# Altium schematic sheet AA83_ProberCard_V0.SchDoc
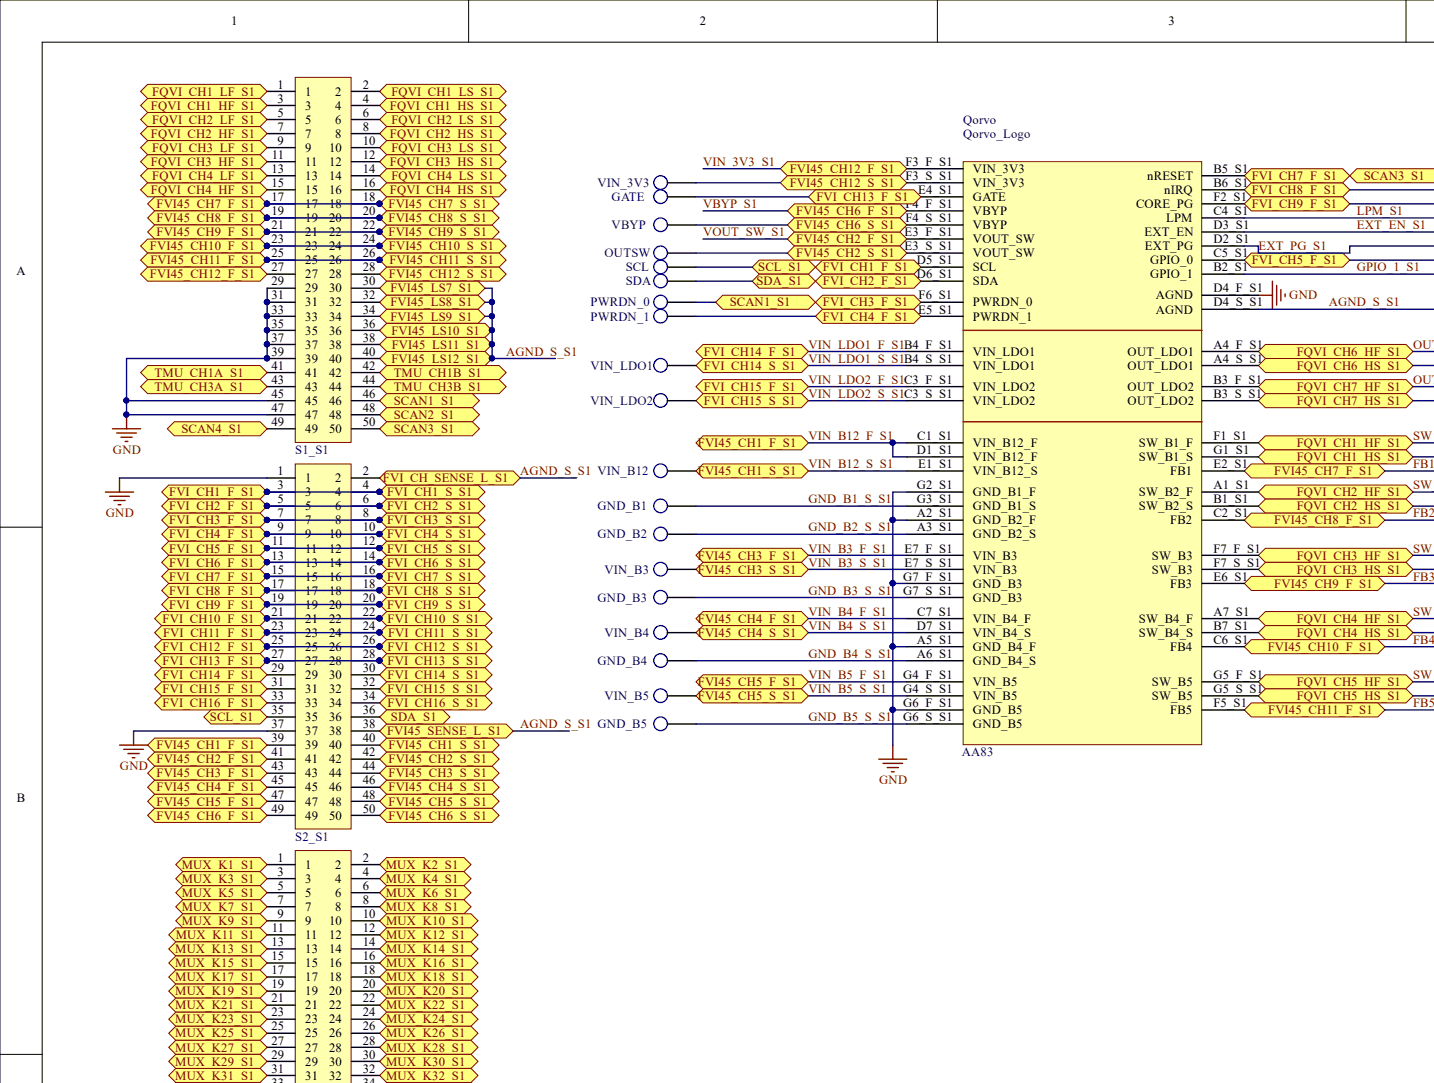
[diagram p0: AA83_ProberCard_V0.SchDoc, top left]
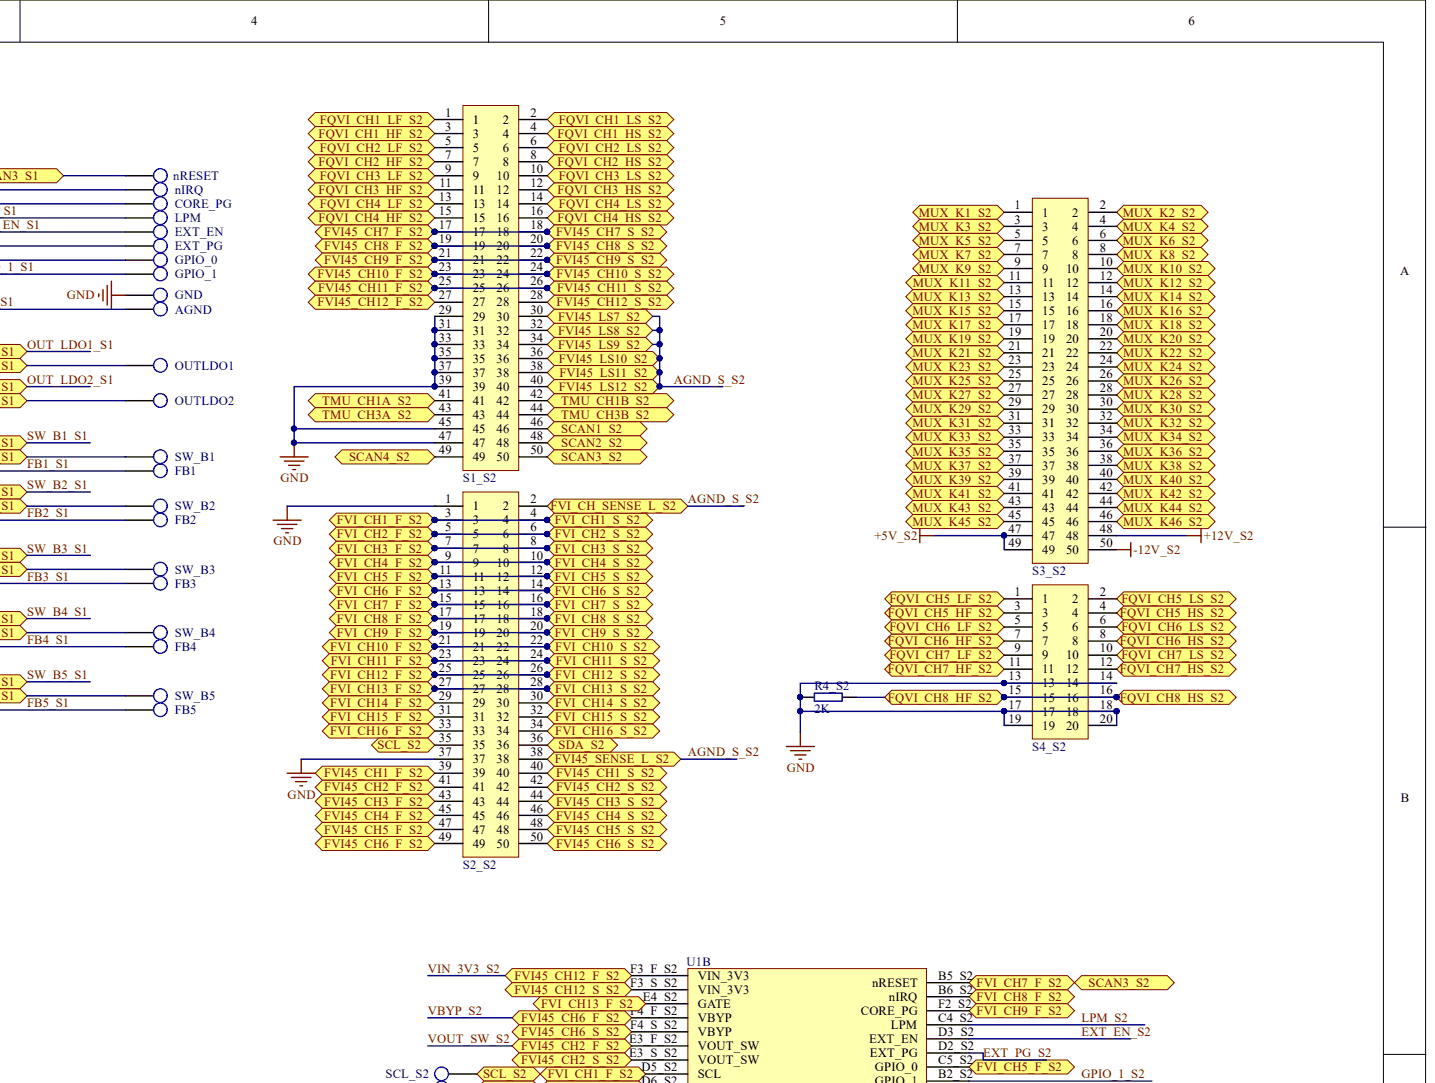
[diagram p1: AA83_ProberCard_V0.SchDoc, top right]
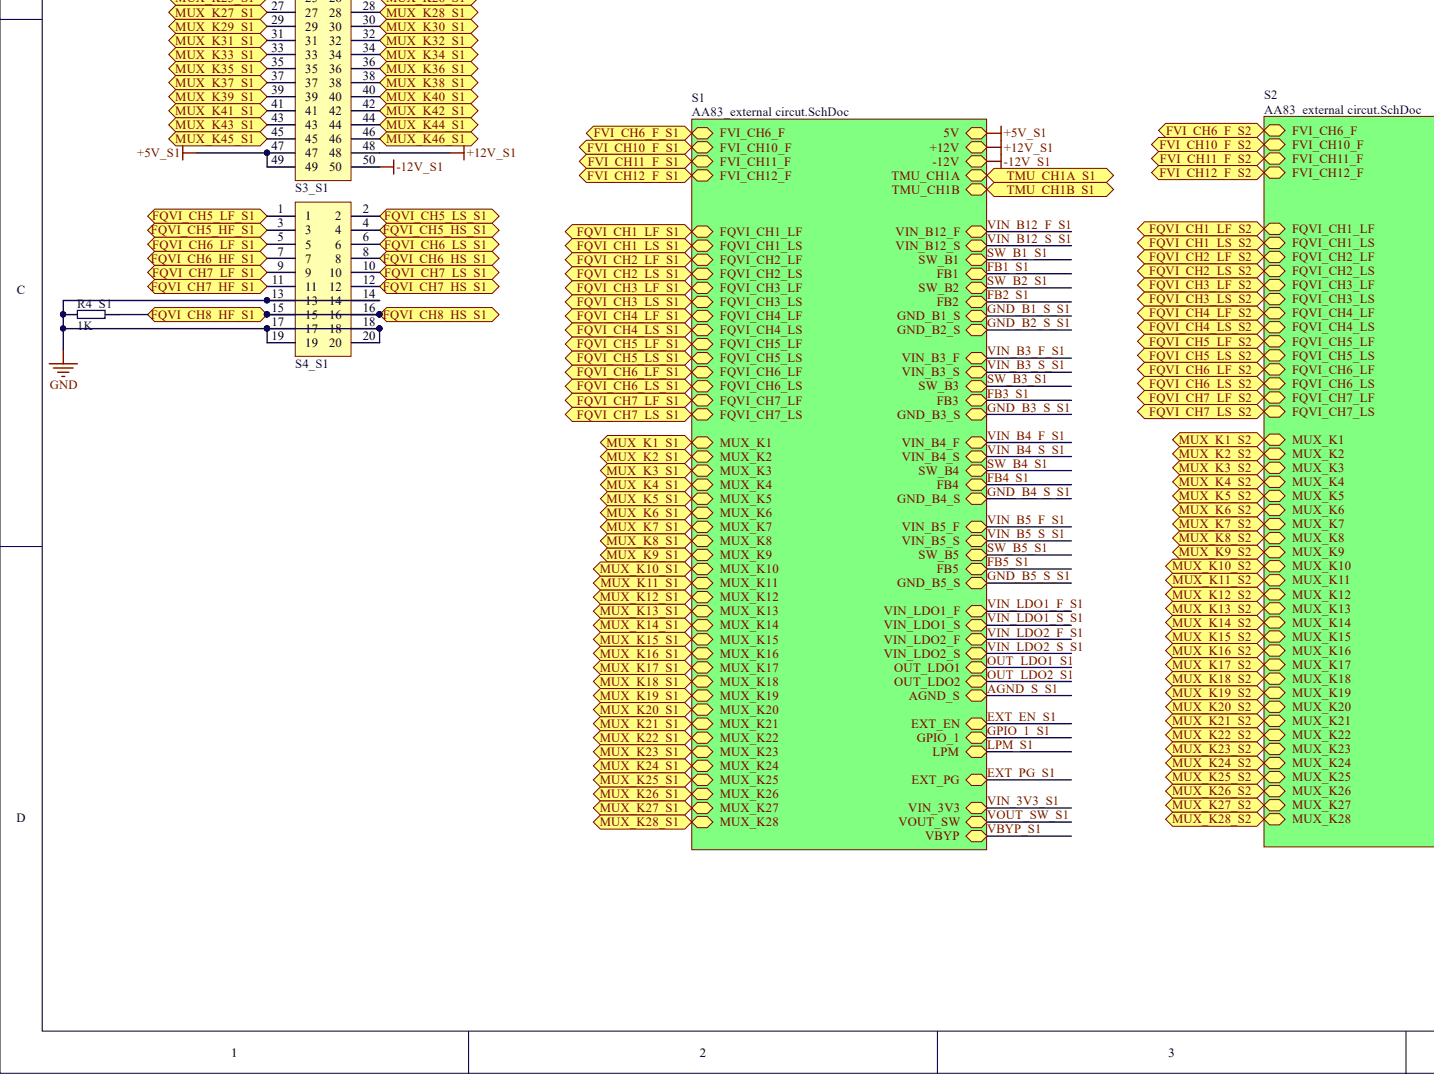
[diagram p2: AA83_ProberCard_V0.SchDoc, bottom left]
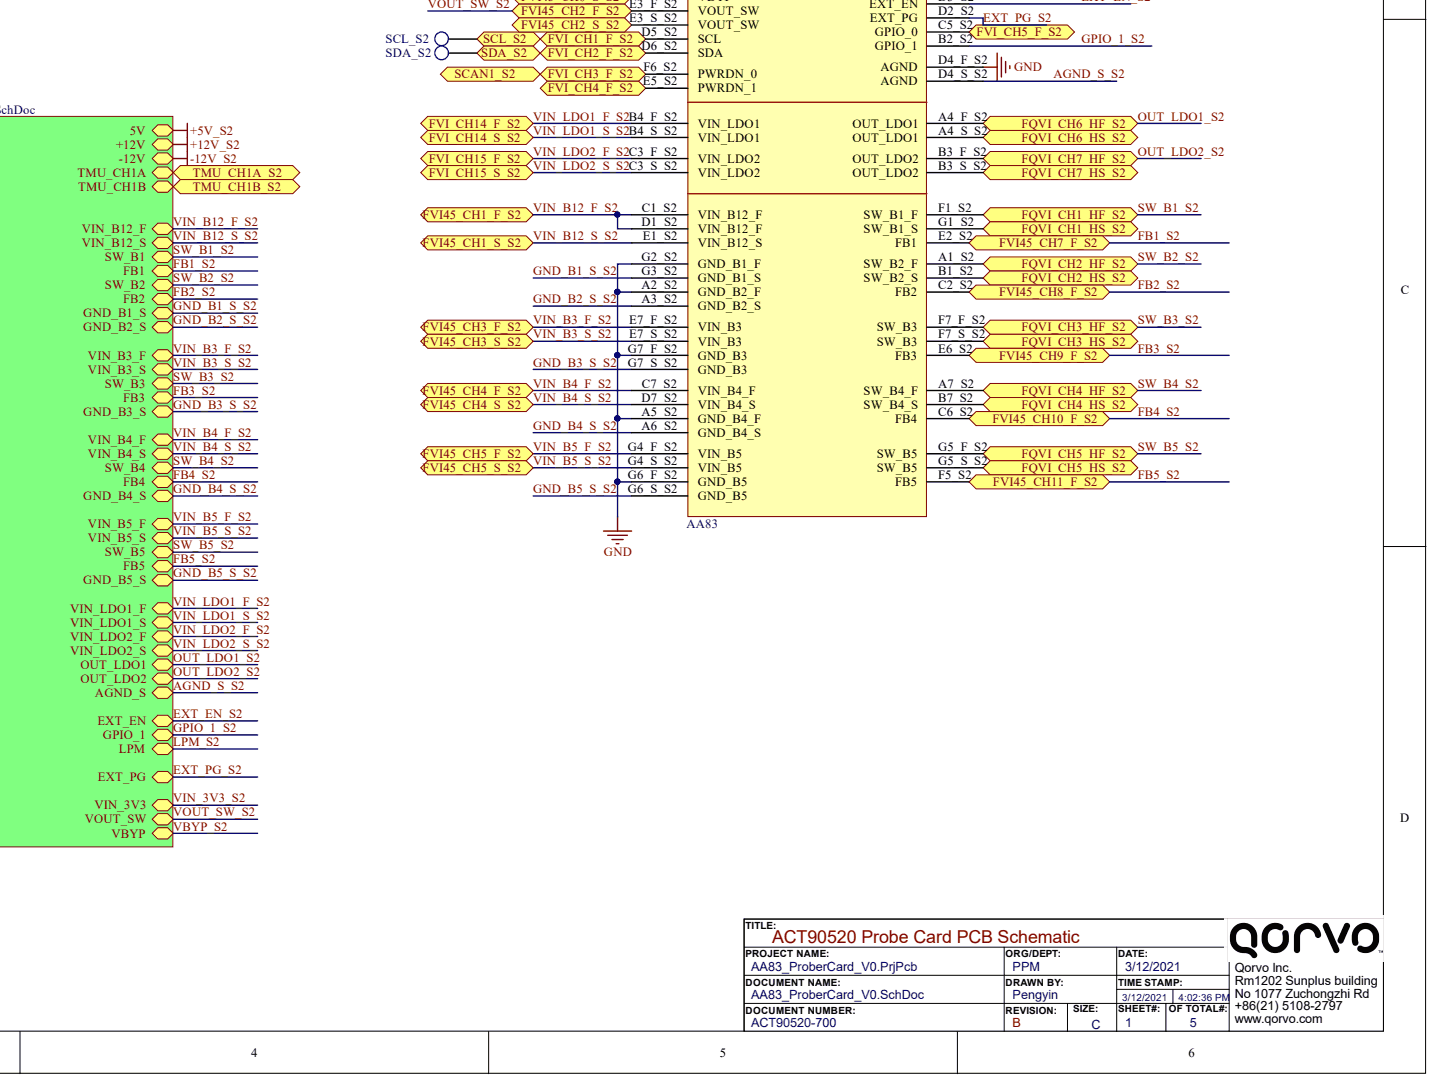
[diagram p3: AA83_ProberCard_V0.SchDoc, bottom right]

## Parts
AGND  TP  [TP]  (value not drawn)
CORE_PG  TP  [TP]  (value not drawn)
EXT_EN  TP  [TP]  (value not drawn)
EXT_PG  TP  [TP]  (value not drawn)
FB1  TP  [TP]  (value not drawn)
FB2  TP  [TP]  (value not drawn)
FB3  TP  [TP]  (value not drawn)
FB4  TP  [TP]  (value not drawn)
FB5  TP  [TP]  (value not drawn)
GATE  TP  [TP]  (value not drawn)
GND  TP  [TP]  (value not drawn)
GND_B1  TP  [TP]  (value not drawn)
GND_B2  TP  [TP]  (value not drawn)
GND_B3  TP  [TP]  (value not drawn)
GND_B4  TP  [TP]  (value not drawn)
GND_B5  TP  [TP]  (value not drawn)
GPIO_0  TP  [TP]  (value not drawn)
GPIO_1  TP  [TP]  (value not drawn)
LPM  TP  [TP]  (value not drawn)
nIRQ  TP  [TP]  (value not drawn)
nRESET  TP  [TP]  (value not drawn)
OUTLDO1  TP  [TP]  (value not drawn)
OUTLDO2  TP  [TP]  (value not drawn)
OUTSW  TP  [TP]  (value not drawn)
PWRDN_0  TP  [TP]  (value not drawn)
PWRDN_1  TP  [TP]  (value not drawn)
Qorvo_Logo  Logo  [LOGO-Qorvo]
R4_S1  value 1K  [0603]  (hidden comment: Resistor)
R4_S2  value 2K  [0603]  (hidden comment: Resistor)
S1_S1  CONN50-Horn  [CONN-DIP-50PIN-Horn]  (value not drawn)
S1_S2  CONN50-Horn  [CONN-DIP-50PIN-Horn]  (value not drawn)
S2_S1  CONN50-Horn  [CONN-DIP-50PIN-Horn]  (value not drawn)
S2_S2  CONN50-Horn  [CONN-DIP-50PIN-Horn]  (value not drawn)
S3_S1  CONN50-Horn  [CONN-DIP-50PIN-Horn]  (value not drawn)
S3_S2  CONN50-Horn  [CONN-DIP-50PIN-Horn]  (value not drawn)
S4_S1  CONN20-Horn  [CONN-DIP-20PIN-Horn]  (value not drawn)
S4_S2  CONN20-Horn  [CONN-DIP-20PIN-Horn]  (value not drawn)
SCL  TP  [TP]  (value not drawn)
SCL_S2  TP  [TP]  (value not drawn)
SDA  TP  [TP]  (value not drawn)
SDA_S2  TP  [TP]  (value not drawn)
SW_B1  TP  [TP]  (value not drawn)
SW_B2  TP  [TP]  (value not drawn)
SW_B3  TP  [TP]  (value not drawn)
SW_B4  TP  [TP]  (value not drawn)
SW_B5  TP  [TP]  (value not drawn)
U1  AA83  [AA83]  (parts A,B)
VBYP  TP  [TP]  (value not drawn)
VIN_3V3  TP  [TP]  (value not drawn)
VIN_B3  TP  [TP]  (value not drawn)
VIN_B4  TP  [TP]  (value not drawn)
VIN_B5  TP  [TP]  (value not drawn)
VIN_B12  TP  [TP]  (value not drawn)
VIN_LDO1  TP  [TP]  (value not drawn)
VIN_LDO2  TP  [TP]  (value not drawn)

## Ports
FQVI_CH1_HF_S1, FQVI_CH1_HF_S2, FQVI_CH1_HS_S1, FQVI_CH1_HS_S2, FQVI_CH1_LF_S1, FQVI_CH1_LF_S2, FQVI_CH1_LS_S1, FQVI_CH1_LS_S2, FQVI_CH2_HF_S1, FQVI_CH2_HF_S2, FQVI_CH2_HS_S1, FQVI_CH2_HS_S2, FQVI_CH2_LF_S1, FQVI_CH2_LF_S2, FQVI_CH2_LS_S1, FQVI_CH2_LS_S2, FQVI_CH3_HF_S1, FQVI_CH3_HF_S2, FQVI_CH3_HS_S1, FQVI_CH3_HS_S2, FQVI_CH3_LF_S1, FQVI_CH3_LF_S2, FQVI_CH3_LS_S1, FQVI_CH3_LS_S2, FQVI_CH4_HF_S1, FQVI_CH4_HF_S2, FQVI_CH4_HS_S1, FQVI_CH4_HS_S2, FQVI_CH4_LF_S1, FQVI_CH4_LF_S2, FQVI_CH4_LS_S1, FQVI_CH4_LS_S2, FQVI_CH5_HF_S1, FQVI_CH5_HF_S2, FQVI_CH5_HS_S1, FQVI_CH5_HS_S2, FQVI_CH5_LF_S1, FQVI_CH5_LF_S2, FQVI_CH5_LS_S1, FQVI_CH5_LS_S2, FQVI_CH6_HF_S1, FQVI_CH6_HF_S2, FQVI_CH6_HS_S1, FQVI_CH6_HS_S2, FQVI_CH6_LF_S1, FQVI_CH6_LF_S2, FQVI_CH6_LS_S1, FQVI_CH6_LS_S2, FQVI_CH7_HF_S1, FQVI_CH7_HF_S2, FQVI_CH7_HS_S1, FQVI_CH7_HS_S2, FQVI_CH7_LF_S1, FQVI_CH7_LF_S2, FQVI_CH7_LS_S1, FQVI_CH7_LS_S2, FQVI_CH8_HF_S1, FQVI_CH8_HF_S2, FQVI_CH8_HS_S1, FQVI_CH8_HS_S2, FVI45_CH1_F_S1, FVI45_CH1_F_S2, FVI45_CH1_S_S1, FVI45_CH1_S_S2, FVI45_CH2_F_S1, FVI45_CH2_F_S2, FVI45_CH2_S_S1, FVI45_CH2_S_S2, FVI45_CH3_F_S1, FVI45_CH3_F_S2, FVI45_CH3_S_S1, FVI45_CH3_S_S2, FVI45_CH4_F_S1, FVI45_CH4_F_S2, FVI45_CH4_S_S1, FVI45_CH4_S_S2, FVI45_CH5_F_S1, FVI45_CH5_F_S2, FVI45_CH5_S_S1, FVI45_CH5_S_S2, FVI45_CH6_F_S1, FVI45_CH6_F_S2, FVI45_CH6_S_S1, FVI45_CH6_S_S2, FVI45_CH7_F_S1, FVI45_CH7_F_S2, FVI45_CH7_S_S1, FVI45_CH7_S_S2, FVI45_CH8_F_S1, FVI45_CH8_F_S2, FVI45_CH8_S_S1, FVI45_CH8_S_S2, FVI45_CH9_F_S1, FVI45_CH9_F_S2, FVI45_CH9_S_S1, FVI45_CH9_S_S2, FVI45_CH10_F_S1, FVI45_CH10_F_S2, FVI45_CH10_S_S1, FVI45_CH10_S_S2, FVI45_CH11_F_S1, FVI45_CH11_F_S2, FVI45_CH11_S_S1, FVI45_CH11_S_S2, FVI45_CH12_F_S1, FVI45_CH12_F_S2, FVI45_CH12_S_S1, FVI45_CH12_S_S2, FVI45_LS7_S1, FVI45_LS7_S2, FVI45_LS8_S1, FVI45_LS8_S2, FVI45_LS9_S1, FVI45_LS9_S2, FVI45_LS10_S1, FVI45_LS10_S2, FVI45_LS11_S1, FVI45_LS11_S2, FVI45_LS12_S1, FVI45_LS12_S2, FVI45_SENSE_L_S1, FVI45_SENSE_L_S2, FVI_CH1_F_S1, FVI_CH1_F_S2, FVI_CH1_S_S1, FVI_CH1_S_S2, FVI_CH2_F_S1, FVI_CH2_F_S2, FVI_CH2_S_S1, FVI_CH2_S_S2, FVI_CH3_F_S1, FVI_CH3_F_S2, FVI_CH3_S_S1, FVI_CH3_S_S2, FVI_CH4_F_S1, FVI_CH4_F_S2, FVI_CH4_S_S1, FVI_CH4_S_S2, FVI_CH5_F_S1, FVI_CH5_F_S2, FVI_CH5_S_S1, FVI_CH5_S_S2, FVI_CH6_F_S1, FVI_CH6_F_S2, FVI_CH6_S_S1, FVI_CH6_S_S2, FVI_CH7_F_S1, FVI_CH7_F_S2, FVI_CH7_S_S1, FVI_CH7_S_S2, FVI_CH8_F_S1, FVI_CH8_F_S2, FVI_CH8_S_S1, FVI_CH8_S_S2, FVI_CH9_F_S1, FVI_CH9_F_S2, FVI_CH9_S_S1, FVI_CH9_S_S2, FVI_CH10_F_S1, FVI_CH10_F_S2, FVI_CH10_S_S1, FVI_CH10_S_S2, FVI_CH11_F_S1, FVI_CH11_F_S2, FVI_CH11_S_S1, FVI_CH11_S_S2, FVI_CH12_F_S1, FVI_CH12_F_S2, FVI_CH12_S_S1, FVI_CH12_S_S2, FVI_CH13_F_S1, FVI_CH13_F_S2, FVI_CH13_S_S1, FVI_CH13_S_S2, FVI_CH14_F_S1, FVI_CH14_F_S2, FVI_CH14_S_S1, FVI_CH14_S_S2, FVI_CH15_F_S1, FVI_CH15_F_S2, FVI_CH15_S_S1, FVI_CH15_S_S2, FVI_CH16_F_S1, FVI_CH16_F_S2, FVI_CH16_S_S1, FVI_CH16_S_S2, FVI_CH_SENSE_L_S1, FVI_CH_SENSE_L_S2, MUX_K1_S1, MUX_K1_S2, MUX_K2_S1, MUX_K2_S2, MUX_K3_S1, MUX_K3_S2, MUX_K4_S1, MUX_K4_S2, MUX_K5_S1, MUX_K5_S2, MUX_K6_S1, MUX_K6_S2, MUX_K7_S1, MUX_K7_S2, MUX_K8_S1, MUX_K8_S2, MUX_K9_S1, MUX_K9_S2, MUX_K10_S1, MUX_K10_S2, MUX_K11_S1, MUX_K11_S2, MUX_K12_S1, MUX_K12_S2, MUX_K13_S1, MUX_K13_S2, MUX_K14_S1, MUX_K14_S2, MUX_K15_S1, MUX_K15_S2, MUX_K16_S1, MUX_K16_S2, MUX_K17_S1, MUX_K17_S2, MUX_K18_S1, MUX_K18_S2, MUX_K19_S1, MUX_K19_S2, MUX_K20_S1, MUX_K20_S2, MUX_K21_S1, MUX_K21_S2, MUX_K22_S1, MUX_K22_S2, MUX_K23_S1, MUX_K23_S2, MUX_K24_S1, MUX_K24_S2, MUX_K25_S1, MUX_K25_S2, MUX_K26_S1, MUX_K26_S2, MUX_K27_S1, MUX_K27_S2, MUX_K28_S1, MUX_K28_S2, MUX_K29_S1, MUX_K29_S2, MUX_K30_S1, MUX_K30_S2, MUX_K31_S1, MUX_K31_S2, MUX_K32_S1, MUX_K32_S2, MUX_K33_S1, MUX_K33_S2, MUX_K34_S1, MUX_K34_S2, MUX_K35_S1, MUX_K35_S2, MUX_K36_S1, MUX_K36_S2, MUX_K37_S1, MUX_K37_S2, MUX_K38_S1, MUX_K38_S2, MUX_K39_S1, MUX_K39_S2, MUX_K40_S1, MUX_K40_S2, MUX_K41_S1, MUX_K41_S2, MUX_K42_S1, MUX_K42_S2, MUX_K43_S1, MUX_K43_S2, MUX_K44_S1, MUX_K44_S2, MUX_K45_S1, MUX_K45_S2, MUX_K46_S1, MUX_K46_S2, SCAN1_S1, SCAN1_S2, SCAN2_S1, SCAN2_S2, SCAN3_S1, SCAN3_S2, SCAN4_S1, SCAN4_S2, SCL_S1, SCL_S2, SDA_S1, SDA_S2, TMU_CH1A_S1, TMU_CH1A_S2, TMU_CH1B_S1, TMU_CH1B_S2, TMU_CH3A_S1, TMU_CH3A_S2, TMU_CH3B_S1, TMU_CH3B_S2

## Nets
+5V_S1: S3_S1.47 S3_S1.49
+5V_S2: S3_S2.47 S3_S2.49
+12V_S1: S3_S1.48
+12V_S2: S3_S2.48
-12V_S1: S3_S1.50
-12V_S2: S3_S2.50
GND: GND.1 R4_S1.1 R4_S2.1 S1_S1.29 S1_S1.31 S1_S1.33 S1_S1.35 S1_S1.37 S1_S1.39 S1_S1.45 S1_S1.47 S1_S2.29 S1_S2.31 S1_S2.33 S1_S2.35 S1_S2.37 S1_S2.39 S1_S2.45 S1_S2.47 S2_S1.1 S2_S1.37 S2_S2.1 S2_S2.37 S4_S1.13 S4_S1.14 S4_S1.17 S4_S1.18 S4_S1.19 S4_S1.20 S4_S2.13 S4_S2.14 S4_S2.17 S4_S2.18 S4_S2.19 S4_S2.20 U1.A2_S1(GND_B2_F) U1.A2_S2(GND_B2_F) U1.A5_S1(GND_B4_F) U1.A5_S2(GND_B4_F) U1.D4_F_S1(AGND) U1.D4_F_S2(AGND) U1.G2_S1(GND_B1_F) U1.G2_S2(GND_B1_F) U1.G6_F_S1(GND_B5) U1.G6_F_S2(GND_B5) U1.G7_F_S1(GND_B3) U1.G7_F_S2(GND_B3)
AGND_S_S1: AGND.1 S1_S1.30 S1_S1.32 S1_S1.34 S1_S1.36 S1_S1.38 S1_S1.40 S2_S1.2 S2_S1.38 U1.D4_S_S1(AGND) ; port FVI45_LS10_S1, port FVI45_LS11_S1, port FVI45_LS12_S1, port FVI45_LS7_S1, port FVI45_LS8_S1, port FVI45_LS9_S1, port FVI45_SENSE_L_S1, port FVI_CH_SENSE_L_S1
AGND_S_S2: S1_S2.30 S1_S2.32 S1_S2.34 S1_S2.36 S1_S2.38 S1_S2.40 S2_S2.2 S2_S2.38 U1.D4_S_S2(AGND) ; port FVI45_LS10_S2, port FVI45_LS11_S2, port FVI45_LS12_S2, port FVI45_LS7_S2, port FVI45_LS8_S2, port FVI45_LS9_S2, port FVI45_SENSE_L_S2, port FVI_CH_SENSE_L_S2
EXT_EN_S1: EXT_EN.1 U1.D3_S1(EXT_EN)
EXT_EN_S2: U1.D3_S2(EXT_EN)
EXT_PG_S1: EXT_PG.1 U1.D2_S1(EXT_PG)
EXT_PG_S2: U1.D2_S2(EXT_PG)
FB1_S1: FB1.1 S1_S1.17 S1_S1.18 U1.E2_S1(FB1) ; port FVI45_CH7_F_S1, port FVI45_CH7_S_S1
FB1_S2: S1_S2.17 S1_S2.18 U1.E2_S2(FB1) ; port FVI45_CH7_F_S2, port FVI45_CH7_S_S2
FB2_S1: FB2.1 S1_S1.19 S1_S1.20 U1.C2_S1(FB2) ; port FVI45_CH8_F_S1, port FVI45_CH8_S_S1
FB2_S2: S1_S2.19 S1_S2.20 U1.C2_S2(FB2) ; port FVI45_CH8_F_S2, port FVI45_CH8_S_S2
FB3_S1: FB3.1 S1_S1.21 S1_S1.22 U1.E6_S1(FB3) ; port FVI45_CH9_F_S1, port FVI45_CH9_S_S1
FB3_S2: S1_S2.21 S1_S2.22 U1.E6_S2(FB3) ; port FVI45_CH9_F_S2, port FVI45_CH9_S_S2
FB4_S1: FB4.1 S1_S1.23 S1_S1.24 U1.C6_S1(FB4) ; port FVI45_CH10_F_S1, port FVI45_CH10_S_S1
FB4_S2: S1_S2.23 S1_S2.24 U1.C6_S2(FB4) ; port FVI45_CH10_F_S2, port FVI45_CH10_S_S2
FB5_S1: FB5.1 S1_S1.25 S1_S1.26 U1.F5_S1(FB5) ; port FVI45_CH11_F_S1, port FVI45_CH11_S_S1
FB5_S2: S1_S2.25 S1_S2.26 U1.F5_S2(FB5) ; port FVI45_CH11_F_S2, port FVI45_CH11_S_S2
FQVI_CH1_HS_S1: S1_S1.4 SW_B1.1 U1.G1_S1(SW_B1_S) ; port FQVI_CH1_HS_S1
FQVI_CH1_HS_S2: S1_S2.4 U1.G1_S2(SW_B1_S) ; port FQVI_CH1_HS_S2
FQVI_CH1_LF_S1: S1_S1.1 ; port FQVI_CH1_LF_S1
FQVI_CH1_LF_S2: S1_S2.1 ; port FQVI_CH1_LF_S2
FQVI_CH1_LS_S1: S1_S1.2 ; port FQVI_CH1_LS_S1
FQVI_CH1_LS_S2: S1_S2.2 ; port FQVI_CH1_LS_S2
FQVI_CH2_HS_S1: S1_S1.8 SW_B2.1 U1.B1_S1(SW_B2_S) ; port FQVI_CH2_HS_S1
FQVI_CH2_HS_S2: S1_S2.8 U1.B1_S2(SW_B2_S) ; port FQVI_CH2_HS_S2
FQVI_CH2_LF_S1: S1_S1.5 ; port FQVI_CH2_LF_S1
FQVI_CH2_LF_S2: S1_S2.5 ; port FQVI_CH2_LF_S2
FQVI_CH2_LS_S1: S1_S1.6 ; port FQVI_CH2_LS_S1
FQVI_CH2_LS_S2: S1_S2.6 ; port FQVI_CH2_LS_S2
FQVI_CH3_HS_S1: S1_S1.12 SW_B3.1 U1.F7_S_S1(SW_B3) ; port FQVI_CH3_HS_S1
FQVI_CH3_HS_S2: S1_S2.12 U1.F7_S_S2(SW_B3) ; port FQVI_CH3_HS_S2
FQVI_CH3_LF_S1: S1_S1.9 ; port FQVI_CH3_LF_S1
FQVI_CH3_LF_S2: S1_S2.9 ; port FQVI_CH3_LF_S2
FQVI_CH3_LS_S1: S1_S1.10 ; port FQVI_CH3_LS_S1
FQVI_CH3_LS_S2: S1_S2.10 ; port FQVI_CH3_LS_S2
FQVI_CH4_HS_S1: S1_S1.16 SW_B4.1 U1.B7_S1(SW_B4_S) ; port FQVI_CH4_HS_S1
FQVI_CH4_HS_S2: S1_S2.16 U1.B7_S2(SW_B4_S) ; port FQVI_CH4_HS_S2
FQVI_CH4_LF_S1: S1_S1.13 ; port FQVI_CH4_LF_S1
FQVI_CH4_LF_S2: S1_S2.13 ; port FQVI_CH4_LF_S2
FQVI_CH4_LS_S1: S1_S1.14 ; port FQVI_CH4_LS_S1
FQVI_CH4_LS_S2: S1_S2.14 ; port FQVI_CH4_LS_S2
FQVI_CH5_HS_S1: S4_S1.4 SW_B5.1 U1.G5_S_S1(SW_B5) ; port FQVI_CH5_HS_S1
FQVI_CH5_HS_S2: S4_S2.4 U1.G5_S_S2(SW_B5) ; port FQVI_CH5_HS_S2
FQVI_CH5_LF_S1: S4_S1.1 ; port FQVI_CH5_LF_S1
FQVI_CH5_LF_S2: S4_S2.1 ; port FQVI_CH5_LF_S2
FQVI_CH5_LS_S1: S4_S1.2 ; port FQVI_CH5_LS_S1
FQVI_CH5_LS_S2: S4_S2.2 ; port FQVI_CH5_LS_S2
FQVI_CH6_HS_S1: OUTLDO1.1 S4_S1.8 U1.A4_S_S1(OUT_LDO1) ; port FQVI_CH6_HS_S1
FQVI_CH6_HS_S2: S4_S2.8 U1.A4_S_S2(OUT_LDO1) ; port FQVI_CH6_HS_S2
FQVI_CH6_LF_S1: S4_S1.5 ; port FQVI_CH6_LF_S1
FQVI_CH6_LF_S2: S4_S2.5 ; port FQVI_CH6_LF_S2
FQVI_CH6_LS_S1: S4_S1.6 ; port FQVI_CH6_LS_S1
FQVI_CH6_LS_S2: S4_S2.6 ; port FQVI_CH6_LS_S2
FQVI_CH7_HS_S1: OUTLDO2.1 S4_S1.12 U1.B3_S_S1(OUT_LDO2) ; port FQVI_CH7_HS_S1
FQVI_CH7_HS_S2: S4_S2.12 U1.B3_S_S2(OUT_LDO2) ; port FQVI_CH7_HS_S2
FQVI_CH7_LF_S1: S4_S1.9 ; port FQVI_CH7_LF_S1
FQVI_CH7_LF_S2: S4_S2.9 ; port FQVI_CH7_LF_S2
FQVI_CH7_LS_S1: S4_S1.10 ; port FQVI_CH7_LS_S1
FQVI_CH7_LS_S2: S4_S2.10 ; port FQVI_CH7_LS_S2
FQVI_CH8_HF_S1: R4_S1.2 S4_S1.15 S4_S1.16 ; port FQVI_CH8_HF_S1, port FQVI_CH8_HS_S1
FQVI_CH8_HF_S2: R4_S2.2 S4_S2.15 S4_S2.16 ; port FQVI_CH8_HF_S2, port FQVI_CH8_HS_S2
FVI45_CH2_S_S1: OUTSW.1 S2_S1.42 U1.E3_S_S1(VOUT_SW) ; port FVI45_CH2_S_S1
FVI45_CH2_S_S2: S2_S2.42 U1.E3_S_S2(VOUT_SW) ; port FVI45_CH2_S_S2
FVI45_CH6_S_S1: S2_S1.50 U1.F4_S_S1(VBYP) VBYP.1 ; port FVI45_CH6_S_S1
FVI45_CH6_S_S2: S2_S2.50 U1.F4_S_S2(VBYP) ; port FVI45_CH6_S_S2
FVI45_CH12_S_S1: S1_S1.28 U1.F3_S_S1(VIN_3V3) VIN_3V3.1 ; port FVI45_CH12_S_S1
FVI45_CH12_S_S2: S1_S2.28 U1.F3_S_S2(VIN_3V3) ; port FVI45_CH12_S_S2
FVI_CH1_F_S1: S2_S1.3 S2_S1.4 S2_S1.35 SCL.1 U1.D5_S1(SCL) ; port FVI_CH1_F_S1, port FVI_CH1_S_S1, port SCL_S1
FVI_CH1_F_S2: S2_S2.3 S2_S2.4 S2_S2.35 SCL_S2.1 U1.D5_S2(SCL) ; port FVI_CH1_F_S2, port FVI_CH1_S_S2, port SCL_S2
FVI_CH2_F_S1: S2_S1.5 S2_S1.6 S2_S1.36 SDA.1 U1.D6_S1(SDA) ; port FVI_CH2_F_S1, port FVI_CH2_S_S1, port SDA_S1
FVI_CH2_F_S2: S2_S2.5 S2_S2.6 S2_S2.36 SDA_S2.1 U1.D6_S2(SDA) ; port FVI_CH2_F_S2, port FVI_CH2_S_S2, port SDA_S2
FVI_CH3_F_S1: PWRDN_0.1 S1_S1.46 S2_S1.7 S2_S1.8 U1.F6_S1(PWRDN_0) ; port FVI_CH3_F_S1, port FVI_CH3_S_S1, port SCAN1_S1
FVI_CH3_F_S2: S1_S2.46 S2_S2.7 S2_S2.8 U1.F6_S2(PWRDN_0) ; port FVI_CH3_F_S2, port FVI_CH3_S_S2, port SCAN1_S2
FVI_CH4_F_S1: PWRDN_1.1 S2_S1.9 S2_S1.10 U1.E5_S1(PWRDN_1) ; port FVI_CH4_F_S1, port FVI_CH4_S_S1
FVI_CH4_F_S2: S2_S2.9 S2_S2.10 U1.E5_S2(PWRDN_1) ; port FVI_CH4_F_S2, port FVI_CH4_S_S2
FVI_CH5_F_S1: GPIO_0.1 S2_S1.11 S2_S1.12 U1.C5_S1(GPIO_0) ; port FVI_CH5_F_S1, port FVI_CH5_S_S1
FVI_CH5_F_S2: S2_S2.11 S2_S2.12 U1.C5_S2(GPIO_0) ; port FVI_CH5_F_S2, port FVI_CH5_S_S2
FVI_CH6_F_S1: S2_S1.13 S2_S1.14 ; port FVI_CH6_F_S1, port FVI_CH6_S_S1
FVI_CH6_F_S2: S2_S2.13 S2_S2.14 ; port FVI_CH6_F_S2, port FVI_CH6_S_S2
FVI_CH7_F_S1: nRESET.1 S1_S1.50 S2_S1.15 S2_S1.16 U1.B5_S1(nRESET) ; port FVI_CH7_F_S1, port FVI_CH7_S_S1, port SCAN3_S1
FVI_CH7_F_S2: S1_S2.50 S2_S2.15 S2_S2.16 U1.B5_S2(nRESET) ; port FVI_CH7_F_S2, port FVI_CH7_S_S2, port SCAN3_S2
FVI_CH8_F_S1: nIRQ.1 S2_S1.17 S2_S1.18 U1.B6_S1(nIRQ) ; port FVI_CH8_F_S1, port FVI_CH8_S_S1
FVI_CH8_F_S2: S2_S2.17 S2_S2.18 U1.B6_S2(nIRQ) ; port FVI_CH8_F_S2, port FVI_CH8_S_S2
FVI_CH9_F_S1: CORE_PG.1 S2_S1.19 S2_S1.20 U1.F2_S1(CORE_PG) ; port FVI_CH9_F_S1, port FVI_CH9_S_S1
FVI_CH9_F_S2: S2_S2.19 S2_S2.20 U1.F2_S2(CORE_PG) ; port FVI_CH9_F_S2, port FVI_CH9_S_S2
FVI_CH10_F_S1: S2_S1.21 S2_S1.22 ; port FVI_CH10_F_S1, port FVI_CH10_S_S1
FVI_CH10_F_S2: S2_S2.21 S2_S2.22 ; port FVI_CH10_F_S2, port FVI_CH10_S_S2
FVI_CH11_F_S1: S2_S1.23 S2_S1.24 ; port FVI_CH11_F_S1, port FVI_CH11_S_S1
FVI_CH11_F_S2: S2_S2.23 S2_S2.24 ; port FVI_CH11_F_S2, port FVI_CH11_S_S2
FVI_CH12_F_S1: S2_S1.25 S2_S1.26 ; port FVI_CH12_F_S1, port FVI_CH12_S_S1
FVI_CH12_F_S2: S2_S2.25 S2_S2.26 ; port FVI_CH12_F_S2, port FVI_CH12_S_S2
FVI_CH13_F_S1: GATE.1 S2_S1.27 S2_S1.28 U1.E4_S1(GATE) ; port FVI_CH13_F_S1, port FVI_CH13_S_S1
FVI_CH13_F_S2: S2_S2.27 S2_S2.28 U1.E4_S2(GATE) ; port FVI_CH13_F_S2, port FVI_CH13_S_S2
FVI_CH16_F_S1: S2_S1.33 ; port FVI_CH16_F_S1
FVI_CH16_F_S2: S2_S2.33 ; port FVI_CH16_F_S2
FVI_CH16_S_S1: S2_S1.34 ; port FVI_CH16_S_S1
FVI_CH16_S_S2: S2_S2.34 ; port FVI_CH16_S_S2
GND_B1_S_S1: GND_B1.1 U1.G3_S1(GND_B1_S)
GND_B1_S_S2: U1.G3_S2(GND_B1_S)
GND_B2_S_S1: GND_B2.1 U1.A3_S1(GND_B2_S)
GND_B2_S_S2: U1.A3_S2(GND_B2_S)
GND_B3_S_S1: GND_B3.1 U1.G7_S_S1(GND_B3)
GND_B3_S_S2: U1.G7_S_S2(GND_B3)
GND_B4_S_S1: GND_B4.1 U1.A6_S1(GND_B4_S)
GND_B4_S_S2: U1.A6_S2(GND_B4_S)
GND_B5_S_S1: GND_B5.1 U1.G6_S_S1(GND_B5)
GND_B5_S_S2: U1.G6_S_S2(GND_B5)
GPIO_1_S1: GPIO_1.1 U1.B2_S1(GPIO_1)
GPIO_1_S2: U1.B2_S2(GPIO_1)
LPM_S1: LPM.1 U1.C4_S1(LPM)
LPM_S2: U1.C4_S2(LPM)
MUX_K1_S1: S3_S1.1 ; port MUX_K1_S1
MUX_K1_S2: S3_S2.1 ; port MUX_K1_S2
MUX_K2_S1: S3_S1.2 ; port MUX_K2_S1
MUX_K2_S2: S3_S2.2 ; port MUX_K2_S2
MUX_K3_S1: S3_S1.3 ; port MUX_K3_S1
MUX_K3_S2: S3_S2.3 ; port MUX_K3_S2
MUX_K4_S1: S3_S1.4 ; port MUX_K4_S1
MUX_K4_S2: S3_S2.4 ; port MUX_K4_S2
MUX_K5_S1: S3_S1.5 ; port MUX_K5_S1
MUX_K5_S2: S3_S2.5 ; port MUX_K5_S2
MUX_K6_S1: S3_S1.6 ; port MUX_K6_S1
MUX_K6_S2: S3_S2.6 ; port MUX_K6_S2
MUX_K7_S1: S3_S1.7 ; port MUX_K7_S1
MUX_K7_S2: S3_S2.7 ; port MUX_K7_S2
MUX_K8_S1: S3_S1.8 ; port MUX_K8_S1
MUX_K8_S2: S3_S2.8 ; port MUX_K8_S2
MUX_K9_S1: S3_S1.9 ; port MUX_K9_S1
MUX_K9_S2: S3_S2.9 ; port MUX_K9_S2
MUX_K10_S1: S3_S1.10 ; port MUX_K10_S1
MUX_K10_S2: S3_S2.10 ; port MUX_K10_S2
MUX_K11_S1: S3_S1.11 ; port MUX_K11_S1
MUX_K11_S2: S3_S2.11 ; port MUX_K11_S2
MUX_K12_S1: S3_S1.12 ; port MUX_K12_S1
MUX_K12_S2: S3_S2.12 ; port MUX_K12_S2
MUX_K13_S1: S3_S1.13 ; port MUX_K13_S1
MUX_K13_S2: S3_S2.13 ; port MUX_K13_S2
MUX_K14_S1: S3_S1.14 ; port MUX_K14_S1
MUX_K14_S2: S3_S2.14 ; port MUX_K14_S2
MUX_K15_S1: S3_S1.15 ; port MUX_K15_S1
MUX_K15_S2: S3_S2.15 ; port MUX_K15_S2
MUX_K16_S1: S3_S1.16 ; port MUX_K16_S1
MUX_K16_S2: S3_S2.16 ; port MUX_K16_S2
MUX_K17_S1: S3_S1.17 ; port MUX_K17_S1
MUX_K17_S2: S3_S2.17 ; port MUX_K17_S2
MUX_K18_S1: S3_S1.18 ; port MUX_K18_S1
MUX_K18_S2: S3_S2.18 ; port MUX_K18_S2
MUX_K19_S1: S3_S1.19 ; port MUX_K19_S1
MUX_K19_S2: S3_S2.19 ; port MUX_K19_S2
MUX_K20_S1: S3_S1.20 ; port MUX_K20_S1
MUX_K20_S2: S3_S2.20 ; port MUX_K20_S2
MUX_K21_S1: S3_S1.21 ; port MUX_K21_S1
MUX_K21_S2: S3_S2.21 ; port MUX_K21_S2
MUX_K22_S1: S3_S1.22 ; port MUX_K22_S1
MUX_K22_S2: S3_S2.22 ; port MUX_K22_S2
MUX_K23_S1: S3_S1.23 ; port MUX_K23_S1
MUX_K23_S2: S3_S2.23 ; port MUX_K23_S2
MUX_K24_S1: S3_S1.24 ; port MUX_K24_S1
MUX_K24_S2: S3_S2.24 ; port MUX_K24_S2
MUX_K25_S1: S3_S1.25 ; port MUX_K25_S1
MUX_K25_S2: S3_S2.25 ; port MUX_K25_S2
MUX_K26_S1: S3_S1.26 ; port MUX_K26_S1
MUX_K26_S2: S3_S2.26 ; port MUX_K26_S2
MUX_K27_S1: S3_S1.27 ; port MUX_K27_S1
MUX_K27_S2: S3_S2.27 ; port MUX_K27_S2
MUX_K28_S1: S3_S1.28 ; port MUX_K28_S1
MUX_K28_S2: S3_S2.28 ; port MUX_K28_S2
MUX_K29_S1: S3_S1.29 ; port MUX_K29_S1
MUX_K29_S2: S3_S2.29 ; port MUX_K29_S2
MUX_K30_S1: S3_S1.30 ; port MUX_K30_S1
MUX_K30_S2: S3_S2.30 ; port MUX_K30_S2
MUX_K31_S1: S3_S1.31 ; port MUX_K31_S1
MUX_K31_S2: S3_S2.31 ; port MUX_K31_S2
MUX_K32_S1: S3_S1.32 ; port MUX_K32_S1
MUX_K32_S2: S3_S2.32 ; port MUX_K32_S2
MUX_K33_S1: S3_S1.33 ; port MUX_K33_S1
MUX_K33_S2: S3_S2.33 ; port MUX_K33_S2
MUX_K34_S1: S3_S1.34 ; port MUX_K34_S1
MUX_K34_S2: S3_S2.34 ; port MUX_K34_S2
MUX_K35_S1: S3_S1.35 ; port MUX_K35_S1
MUX_K35_S2: S3_S2.35 ; port MUX_K35_S2
MUX_K36_S1: S3_S1.36 ; port MUX_K36_S1
MUX_K36_S2: S3_S2.36 ; port MUX_K36_S2
MUX_K37_S1: S3_S1.37 ; port MUX_K37_S1
MUX_K37_S2: S3_S2.37 ; port MUX_K37_S2
MUX_K38_S1: S3_S1.38 ; port MUX_K38_S1
MUX_K38_S2: S3_S2.38 ; port MUX_K38_S2
MUX_K39_S1: S3_S1.39 ; port MUX_K39_S1
MUX_K39_S2: S3_S2.39 ; port MUX_K39_S2
MUX_K40_S1: S3_S1.40 ; port MUX_K40_S1
MUX_K40_S2: S3_S2.40 ; port MUX_K40_S2
MUX_K41_S1: S3_S1.41 ; port MUX_K41_S1
MUX_K41_S2: S3_S2.41 ; port MUX_K41_S2
MUX_K42_S1: S3_S1.42 ; port MUX_K42_S1
MUX_K42_S2: S3_S2.42 ; port MUX_K42_S2
MUX_K43_S1: S3_S1.43 ; port MUX_K43_S1
MUX_K43_S2: S3_S2.43 ; port MUX_K43_S2
MUX_K44_S1: S3_S1.44 ; port MUX_K44_S1
MUX_K44_S2: S3_S2.44 ; port MUX_K44_S2
MUX_K45_S1: S3_S1.45 ; port MUX_K45_S1
MUX_K45_S2: S3_S2.45 ; port MUX_K45_S2
MUX_K46_S1: S3_S1.46 ; port MUX_K46_S1
MUX_K46_S2: S3_S2.46 ; port MUX_K46_S2
OUT_LDO1_S1: S4_S1.7 U1.A4_F_S1(OUT_LDO1) ; port FQVI_CH6_HF_S1
OUT_LDO1_S2: S4_S2.7 U1.A4_F_S2(OUT_LDO1) ; port FQVI_CH6_HF_S2
OUT_LDO2_S1: S4_S1.11 U1.B3_F_S1(OUT_LDO2) ; port FQVI_CH7_HF_S1
OUT_LDO2_S2: S4_S2.11 U1.B3_F_S2(OUT_LDO2) ; port FQVI_CH7_HF_S2
SCAN2_S1: S1_S1.48 ; port SCAN2_S1
SCAN2_S2: S1_S2.48 ; port SCAN2_S2
SCAN4_S1: S1_S1.49 ; port SCAN4_S1
SCAN4_S2: S1_S2.49 ; port SCAN4_S2
SW_B1_S1: S1_S1.3 U1.F1_S1(SW_B1_F) ; port FQVI_CH1_HF_S1
SW_B1_S2: S1_S2.3 U1.F1_S2(SW_B1_F) ; port FQVI_CH1_HF_S2
SW_B2_S1: S1_S1.7 U1.A1_S1(SW_B2_F) ; port FQVI_CH2_HF_S1
SW_B2_S2: S1_S2.7 U1.A1_S2(SW_B2_F) ; port FQVI_CH2_HF_S2
SW_B3_S1: S1_S1.11 U1.F7_F_S1(SW_B3) ; port FQVI_CH3_HF_S1
SW_B3_S2: S1_S2.11 U1.F7_F_S2(SW_B3) ; port FQVI_CH3_HF_S2
SW_B4_S1: S1_S1.15 U1.A7_S1(SW_B4_F) ; port FQVI_CH4_HF_S1
SW_B4_S2: S1_S2.15 U1.A7_S2(SW_B4_F) ; port FQVI_CH4_HF_S2
SW_B5_S1: S4_S1.3 U1.G5_F_S1(SW_B5) ; port FQVI_CH5_HF_S1
SW_B5_S2: S4_S2.3 U1.G5_F_S2(SW_B5) ; port FQVI_CH5_HF_S2
TMU_CH1A_S1: S1_S1.41 ; port TMU_CH1A_S1
TMU_CH1A_S2: S1_S2.41 ; port TMU_CH1A_S2
TMU_CH1B_S1: S1_S1.42 ; port TMU_CH1B_S1
TMU_CH1B_S2: S1_S2.42 ; port TMU_CH1B_S2
TMU_CH3A_S1: S1_S1.43 ; port TMU_CH3A_S1
TMU_CH3A_S2: S1_S2.43 ; port TMU_CH3A_S2
TMU_CH3B_S1: S1_S1.44 ; port TMU_CH3B_S1
TMU_CH3B_S2: S1_S2.44 ; port TMU_CH3B_S2
VBYP_S1: S2_S1.49 U1.F4_F_S1(VBYP) ; port FVI45_CH6_F_S1
VBYP_S2: S2_S2.49 U1.F4_F_S2(VBYP) ; port FVI45_CH6_F_S2
VIN_3V3_S1: S1_S1.27 U1.F3_F_S1(VIN_3V3) ; port FVI45_CH12_F_S1
VIN_3V3_S2: S1_S2.27 U1.F3_F_S2(VIN_3V3) ; port FVI45_CH12_F_S2
VIN_B3_F_S1: S2_S1.43 U1.E7_F_S1(VIN_B3) ; port FVI45_CH3_F_S1
VIN_B3_F_S2: S2_S2.43 U1.E7_F_S2(VIN_B3) ; port FVI45_CH3_F_S2
VIN_B3_S_S1: S2_S1.44 U1.E7_S_S1(VIN_B3) VIN_B3.1 ; port FVI45_CH3_S_S1
VIN_B3_S_S2: S2_S2.44 U1.E7_S_S2(VIN_B3) ; port FVI45_CH3_S_S2
VIN_B4_F_S1: S2_S1.45 U1.C7_S1(VIN_B4_F) ; port FVI45_CH4_F_S1
VIN_B4_F_S2: S2_S2.45 U1.C7_S2(VIN_B4_F) ; port FVI45_CH4_F_S2
VIN_B4_S_S1: S2_S1.46 U1.D7_S1(VIN_B4_S) VIN_B4.1 ; port FVI45_CH4_S_S1
VIN_B4_S_S2: S2_S2.46 U1.D7_S2(VIN_B4_S) ; port FVI45_CH4_S_S2
VIN_B5_F_S1: S2_S1.47 U1.G4_F_S1(VIN_B5) ; port FVI45_CH5_F_S1
VIN_B5_F_S2: S2_S2.47 U1.G4_F_S2(VIN_B5) ; port FVI45_CH5_F_S2
VIN_B5_S_S1: S2_S1.48 U1.G4_S_S1(VIN_B5) VIN_B5.1 ; port FVI45_CH5_S_S1
VIN_B5_S_S2: S2_S2.48 U1.G4_S_S2(VIN_B5) ; port FVI45_CH5_S_S2
VIN_B12_F_S1: S2_S1.39 U1.C1_S1(VIN_B12_F) U1.D1_S1(VIN_B12_F) ; port FVI45_CH1_F_S1
VIN_B12_F_S2: S2_S2.39 U1.C1_S2(VIN_B12_F) U1.D1_S2(VIN_B12_F) ; port FVI45_CH1_F_S2
VIN_B12_S_S1: S2_S1.40 U1.E1_S1(VIN_B12_S) VIN_B12.1 ; port FVI45_CH1_S_S1
VIN_B12_S_S2: S2_S2.40 U1.E1_S2(VIN_B12_S) ; port FVI45_CH1_S_S2
VIN_LDO1_F_S1: S2_S1.29 U1.B4_F_S1(VIN_LDO1) ; port FVI_CH14_F_S1
VIN_LDO1_F_S2: S2_S2.29 U1.B4_F_S2(VIN_LDO1) ; port FVI_CH14_F_S2
VIN_LDO1_S_S1: S2_S1.30 U1.B4_S_S1(VIN_LDO1) VIN_LDO1.1 ; port FVI_CH14_S_S1
VIN_LDO1_S_S2: S2_S2.30 U1.B4_S_S2(VIN_LDO1) ; port FVI_CH14_S_S2
VIN_LDO2_F_S1: S2_S1.31 U1.C3_F_S1(VIN_LDO2) ; port FVI_CH15_F_S1
VIN_LDO2_F_S2: S2_S2.31 U1.C3_F_S2(VIN_LDO2) ; port FVI_CH15_F_S2
VIN_LDO2_S_S1: S2_S1.32 U1.C3_S_S1(VIN_LDO2) VIN_LDO2.1 ; port FVI_CH15_S_S1
VIN_LDO2_S_S2: S2_S2.32 U1.C3_S_S2(VIN_LDO2) ; port FVI_CH15_S_S2
VOUT_SW_S1: S2_S1.41 U1.E3_F_S1(VOUT_SW) ; port FVI45_CH2_F_S1
VOUT_SW_S2: S2_S2.41 U1.E3_F_S2(VOUT_SW) ; port FVI45_CH2_F_S2

## Library data of the parts
Qorvo_Logo = Logo_Qorvo: Component Kind: Standard; Library Name: Qorvo_PPM_TE_20200430.SchLib
R4_S1 R4_S2 = Resistor: Acurracy: 5%; Temp: 150C; Component Kind: Standard; Description: Resistor; LatestRevisionDate: 17-Jul-2002; LatestRevisionNote: Re-released for DXP Platform.; Published: 8-Jun-2000; Signal Integrity: Res; Simulation: RESISTOR; Library Name: Qorvo_PPM_TE_20200430.SchLib; Publisher: Altium Limited
S1_S1 S1_S2 S2_S1 S2_S2 S3_S1 S3_S2 = CONN50-Horn: Connector; Component Kind: Standard; Library Name: Qorvo_PPM_TE.IntLib
S4_S1 S4_S2 = CONN20-Horn: Connector; Component Kind: Standard; Library Name: Qorvo_PPM_TE.IntLib
U1 = AA83: TwinSocket WLCSP49, 2 SITE, 0.40; Component Kind: Standard; Library Name: Qorvo_PPM_TE.IntLib
AGND CORE_PG EXT_EN EXT_PG FB1-FB5 GATE GND GND_B1-GND_B5 GPIO_0 GPIO_1 LPM nIRQ nRESET OUTLDO1 OUTLDO2 OUTSW PWRDN_0 PWRDN_1 SCL SCL_S2 SDA SDA_S2 SW_B1-SW_B5 VBYP VIN_3V3 VIN_B3-VIN_B5 VIN_B12 VIN_LDO1 VIN_LDO2 = TP: Component Kind: Standard; Library Name: Qorvo_PPM_TE.IntLib
